# Altium schematic sheet ExtAtTiny.SchDoc
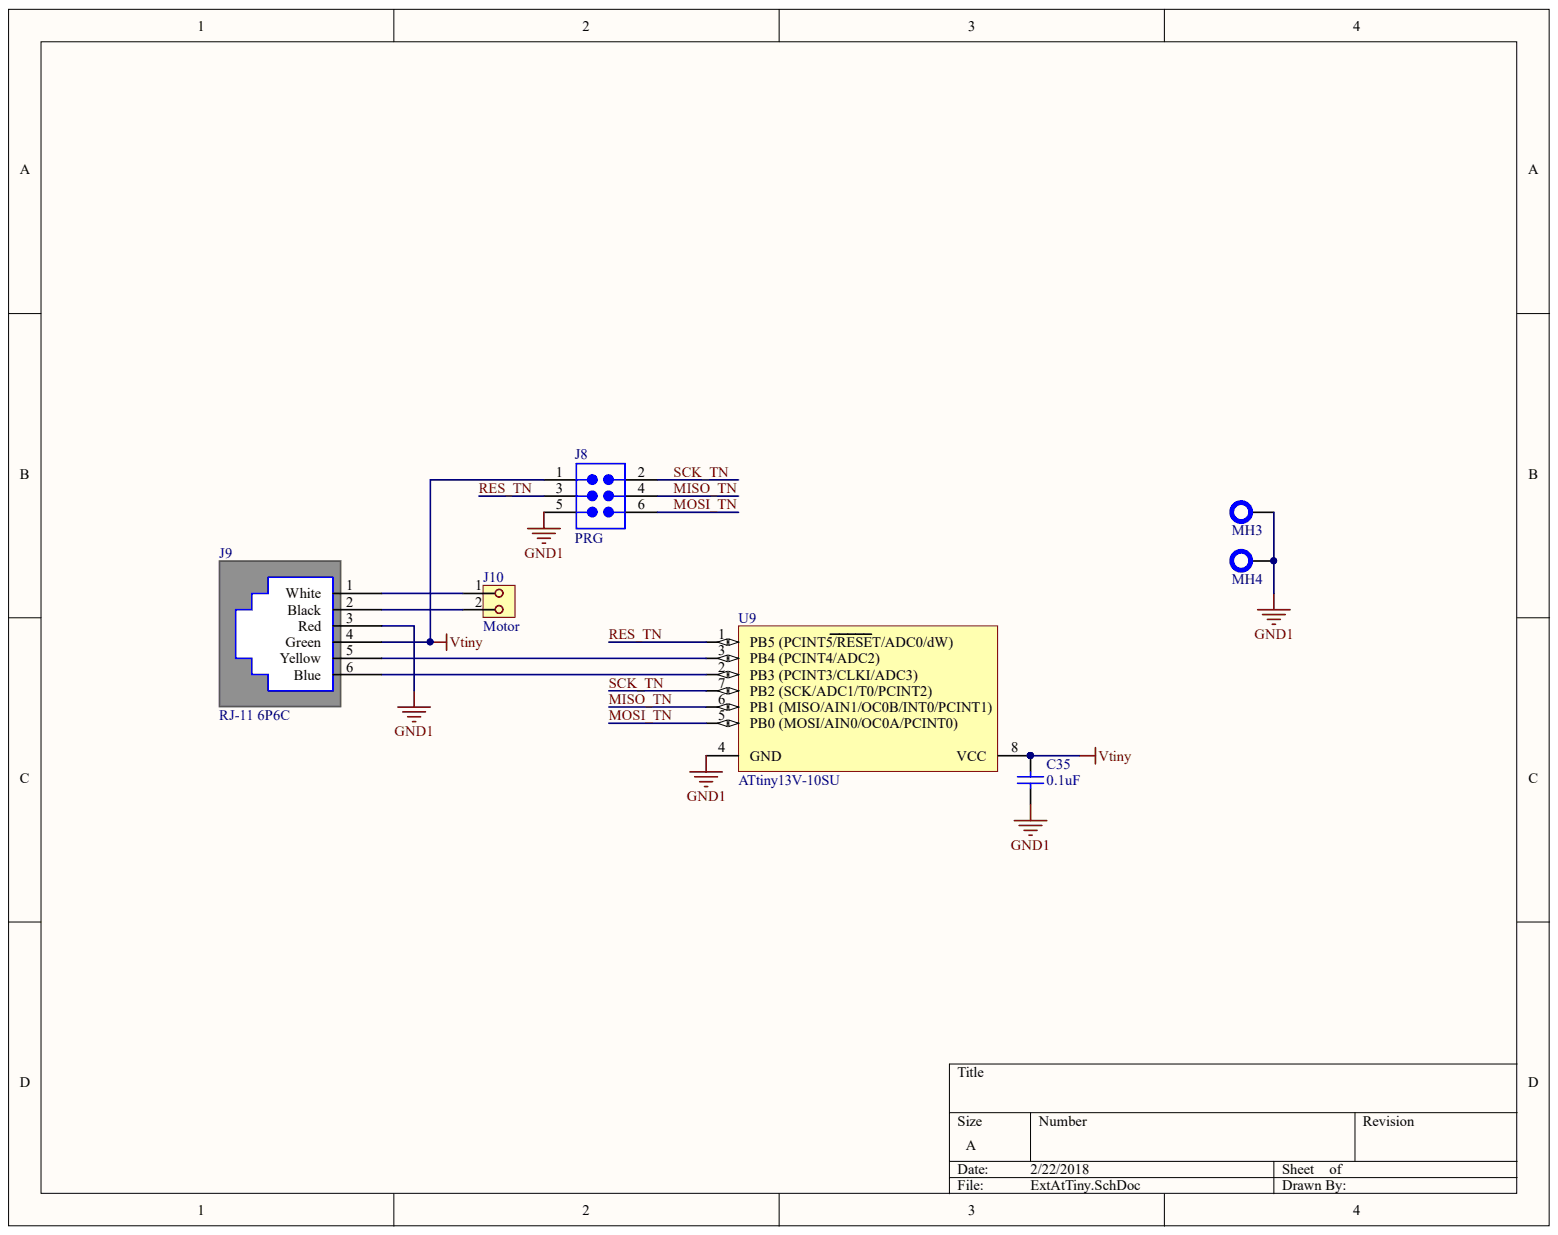
[diagram p0: ExtAtTiny.SchDoc]

## Parts
C35  Capacitance: 0.1uF  [CAPC1608X90X35LL15T15]  (hidden comment: AVX Interconnect / Elco 06036D105KAT2A)
J8  PRG  [PCB-alggvk0m4hnepl8fg6xg-1]
J9  RJ-11 6P6C  [PCB-dhj0y0hoiu0232y63q4o-1]
J10  Motor  [PCB-55llon6gpk2h6a06nz0l-1]
MH3  MountHole  [PCB-d0jqlyxt4wqhvgbnry4h-1]  (value not drawn)
MH4  MountHole  [PCB-d0jqlyxt4wqhvgbnry4h-1]  (value not drawn)
U9  ATtiny13V-10SU  [8S2_N]

## Nets
GND1: C35.1 J8.5 J9.3(Red) MH3.1 MH4.1 U9.4(GND)
VTINY: C35.2 J8.1 J9.4(Green) U9.8(VCC)
MISO_TN: J8.4 U9.6(PB1_(MISO/AIN1/OC0B/INT0/PCINT1))
MOSI_TN: J8.6 U9.5(PB0_(MOSI/AIN0/OC0A/PCINT0))
RES_TN: J8.3 U9.1(PB5_(PCINT5/RESET/ADC0/dW))
SCK_TN: J8.2 U9.7(PB2_(SCK/ADC1/T0/PCINT2))
NetJ9_1: J9.1(White) J10.1
NetJ9_2: J9.2(Black) J10.2
NetJ9_5: J9.5(Yellow) U9.3(PB4_(PCINT4/ADC2))
NetJ9_6: J9.6(Blue) U9.2(PB3_(PCINT3/CLKI/ADC3))

## Library data of the parts
C35 = CMP-126022-2: CAP CER 1UF 6.3V 10% X5R 0603; Dielectric Material: Ceramic Multilayer; Insulation Resistance: 1e+11 Ω; Lead-Free Status: Lead Free; Capacitance Tolerance: ±10%; Case/Package: 0603; Component Kind: Standard; Dielectric Characteristic: X5R; Library Name: CommunityVault; Mounting Style: Surface Mount; Number of Pins: 2; OctopartID: 12fddbf79c04cd3a; Octopart Url: https://octopart.com/06036d105kat2a-avx+interconnect+%2F+elco-43935; REACH SVHC Compliance: No SVHC; RoHS: Compliant; Size-Height: 0.00086 m; Size-Length: 0.0016 m; Size-Thickness: 0.0008636 m; Size-Width: 0.00081 m; Voltage Rating (DC): 6.3 V
J8 = CMP-78cdb343a32fa70a-2: Wire-To-Board Connector, AMP-LATCH Series, Through Hole, Header, 6, 2.54 mm, Gold Plated Contacts; Gender: Male; Lead-Free Status: Lead Free; Number of Contacts: 6; Pin Pitch: 2.54 mm; Color: Blue; Component Kind: Standard; Housing Color: Blue; Housing Material: Thermoplastic; Library Name: CommunityVault; Lifecycle Status: Active; OctopartID: 78cdb343a32fa70a; Octopart URL: http://octopart.com/2-1761603-1-te+connectivity-42270340; Packaging: Bag; REACH SVHC Compliance: No SVHC; RoHS: Compliant
J9 = CMP-33ecfa0a2b0dec2b-2: Conn RJ-11 F 6 POS 1.02mm Solder RA Thru-Hole 6 Terminal 1 Port; Manufacturer Part Number: PT-J623SS-66GR; Component Kind: Standard; Library Name: CommunityVault; Lifecycle Status: Not Listed by Manufacturer; OctopartID: 33ecfa0a2b0dec2b; RoHS: Compliant
J10 = CMP-8fae46aeaafe5069-11: 2.54mm (.100") Pitch C-Grid III Header, Single Row, Vertical, 1 Circuits, Gold (Au) Plating; Manufacturer Part Number: 90120-0762; Contact Resistance: 0.02 ?; Current Rating: 3.0 A; Gender: Male; Glow Wire Compliant: Non-Compliant; Insulation Resistance: 5000000000.0 ?; Lead-Free Status: Lead Free; Number of Circuits: 1; Number of Contacts: 2; Pin Pitch: 0.00254 m; Voltage Rating (AC): 350.0 V; Component Kind: Standard; Contact Material: Brass; Contact Plating: Gold; Halogen Free Status: Not Reviewed; Housing Color: Black; Housing Material: Plastic; Library Name: CommunityVault; Lifecycle Status: Not Listed by Manufacturer; Mounting Style: Through Hole, Vertical; Number of Positions: 2; Number of Rows: 1; OctopartID: 8fae46aeaafe5069; Octopart URL: http://octopart.com/90120-0762-molex-655414; Orientation: Vertical; Packaging: Bag; RoHS: Compliant; Size-Height: 0.0025 m; Size-Length: 0.00508 m; Size-Width: 0.00254 m; Voltage Rating (DC): 350.0 V
MH3 MH4 = CMP-eo2hwbm1pte8m6tn8ao3-1: M3 mounthole with space for 5mm dia stands; Component Kind: Standard; CustomComponentID: eo2hwbm1pte8m6tn8ao3; Library Name: CommunityVault
U9 = CMP-10863155-3: 8-bit AVR Microcontroller, 1.8-5.5V, 1KB Flash, 64 Bytes EEPROM, 64 Bytes SRAM, 8-pin SOIC, Industrial Grade (-40°C to 85°C), Pb-Free; Access Time: 1e-05 s; Clock Speed: 10000000.0 Hz; FLASH Memory Size: 1024 B; Lead-Free Status: Lead Free; Number of Bits: 8; Number of I/O Pins: 6; RAM Memory Size: 64 B; Supply Voltage (DC): 5.0 V; Case/Package: SOIC; Component Kind: Standard; Core Architecture: AVR; Library Name: CommunityVault; Mounting Style: Surface Mount; Number of Pins: 8; OctopartID: dbf511b2bb4d0432; Octopart Url: https://octopart.com/attiny13v-10su-atmel-55395806; Packaging: Tube; REACH SVHC Compliance: No SVHC; RoHS: Compliant
